# Altium schematic sheet apogee_detection_board.SchDoc
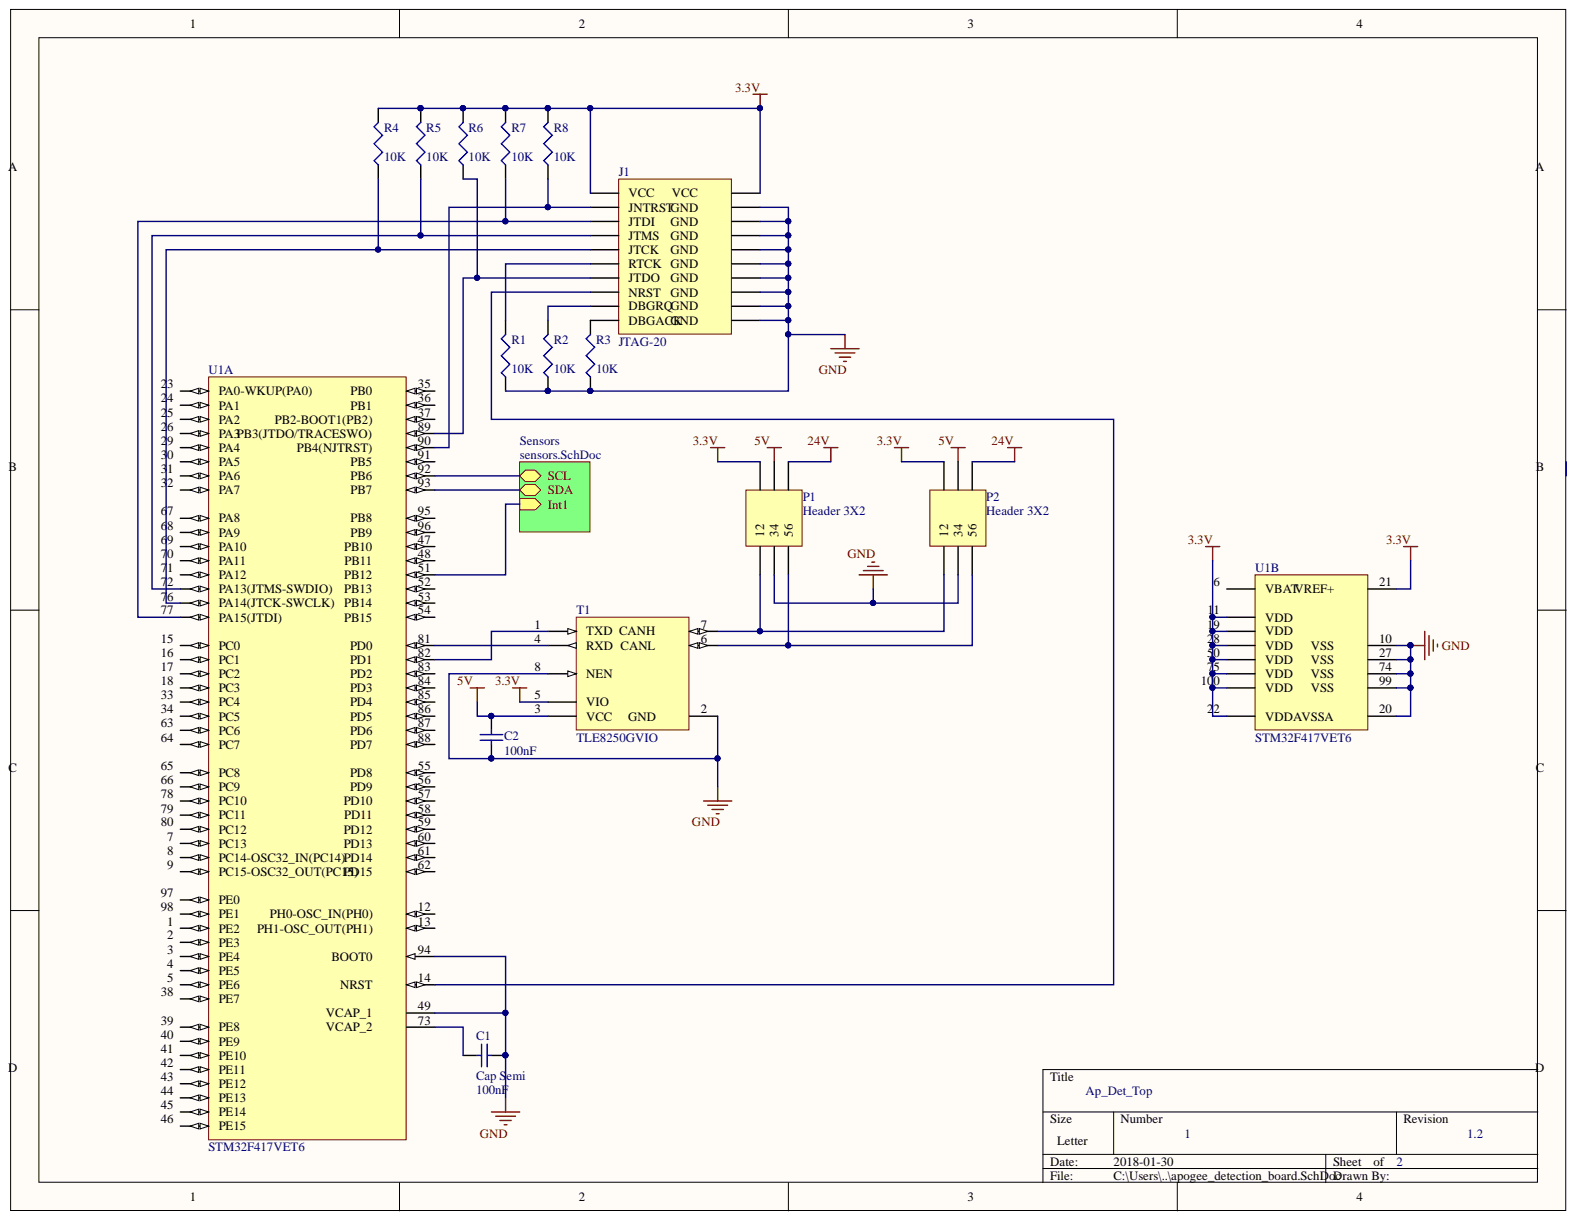
[diagram p0: apogee_detection_board.SchDoc]

## Parts
C1  Cap Semi  value 100nF  [C1206]
C2  value 100nF  [C1206]  (hidden comment: Cap Semi)
J1  JTAG-20  [PCBComponent_1]
P1  Header 3X2  [HDR2X3]
P2  Header 3X2  [HDR2X3]
R1  value 10K  [J1-0603]  (hidden comment: RTCK PD)
R2  value 10K  [J1-0603]  (hidden comment: DBGRQ PD)
R3  value 10K  [J1-0603]  (hidden comment: DBGACK PD)
R4  value 10K  [J1-0603]  (hidden comment: RTCK PD)
R5  value 10K  [J1-0603]  (hidden comment: RTCK PD)
R6  value 10K  [J1-0603]  (hidden comment: RTCK PD)
R7  value 10K  [J1-0603]  (hidden comment: RTCK PD)
R8  value 10K  [J1-0603]  (hidden comment: RTCK PD)
T1  TLE8250GVIO  [INF-PG-DSO-8-16_N]
U1  STM32F417VET6  [STM-LQFP100_N]  (parts A,B)

## Nets
3.3V: J1.1(VCC) J1.2 P1.2 P2.2 R4.2 R5.2 R6.2 R7.2 R8.2 T1.5(VIO) U1.11(VDD) U1.19(VDD) U1.21 U1.22 U1.28(VDD) U1.50(VDD) U1.75(VDD) U1.100(VDD)
5V: C2.2 P1.4 P2.4 T1.3(VCC)
24V: P1.6 P2.6
GND: C1.2 C2.1 J1.4 J1.6 J1.8 J1.10 J1.12 J1.14 J1.16 J1.18 J1.20 P1.3 P2.3 R1.1 R2.1 R3.1 T1.2 T1.8(NEN) U1.10 U1.20 U1.27 U1.49 U1.74 U1.94 U1.99
NetC1_1: C1.1 U1.73
NetJ1_3: J1.3(JNTRSTGND) R8.1 U1.90
NetJ1_5: J1.5(JTDI) R7.1 U1.77(PA15(JTDI))
NetJ1_7: J1.7(JTMS) R5.1 U1.72(PA13(JTMS-SWDIO))
NetJ1_9: J1.9(JTCK) R4.1 U1.76(PA14(JTCK-SWCLK))
NetJ1_11: J1.11(RTCK) R1.2
NetJ1_13: J1.13(JTDO) R6.1 U1.89
NetJ1_15: J1.15(NRST) U1.14
NetJ1_17: J1.17(DBGRQGND) R2.2
NetJ1_19: J1.19(DBGACK) R3.2
NetP1_1: P1.1 P2.1 T1.7
NetP1_5: P1.5 P2.5 T1.6
NetT1_1: T1.1(TXD) U1.82
NetT1_4: T1.4(RXD) U1.81
NetU1_1: U1.1(PE2)
NetU1_2: U1.2(PE3)
NetU1_3: U1.3(PE4)
NetU1_4: U1.4(PE5)
NetU1_5: U1.5(PE6)
NetU1_6: U1.6(VBAT)
NetU1_7: U1.7(PC13)
NetU1_8: U1.8(PC14-OSC32_IN(PC14))
NetU1_9: U1.9(PC15-OSC32_OUT(PC15))
NetU1_12: U1.12
NetU1_13: U1.13
NetU1_15: U1.15(PC0)
NetU1_16: U1.16(PC1)
NetU1_17: U1.17(PC2)
NetU1_18: U1.18(PC3)
NetU1_23: U1.23(PA0-WKUP(PA0))
NetU1_24: U1.24(PA1)
NetU1_25: U1.25(PA2)
NetU1_26: U1.26(PA3)
NetU1_29: U1.29(PA4)
NetU1_30: U1.30(PA5)
NetU1_31: U1.31(PA6)
NetU1_32: U1.32(PA7)
NetU1_33: U1.33(PC4)
NetU1_34: U1.34(PC5)
NetU1_35: U1.35
NetU1_36: U1.36
NetU1_37: U1.37
NetU1_38: U1.38(PE7)
NetU1_39: U1.39(PE8)
NetU1_40: U1.40(PE9)
NetU1_41: U1.41(PE10)
NetU1_42: U1.42(PE11)
NetU1_43: U1.43(PE12)
NetU1_44: U1.44(PE13)
NetU1_45: U1.45(PE14)
NetU1_46: U1.46(PE15)
NetU1_47: U1.47
NetU1_48: U1.48
NetU1_51: U1.51
NetU1_52: U1.52
NetU1_53: U1.53
NetU1_54: U1.54
NetU1_55: U1.55
NetU1_56: U1.56
NetU1_57: U1.57
NetU1_58: U1.58
NetU1_59: U1.59
NetU1_60: U1.60
NetU1_61: U1.61
NetU1_62: U1.62
NetU1_63: U1.63(PC6)
NetU1_64: U1.64(PC7)
NetU1_65: U1.65(PC8)
NetU1_66: U1.66(PC9)
NetU1_67: U1.67(PA8)
NetU1_68: U1.68(PA9)
NetU1_69: U1.69(PA10)
NetU1_70: U1.70(PA11)
NetU1_71: U1.71(PA12)
NetU1_78: U1.78(PC10)
NetU1_79: U1.79(PC11)
NetU1_80: U1.80(PC12)
NetU1_83: U1.83
NetU1_84: U1.84
NetU1_85: U1.85
NetU1_86: U1.86
NetU1_87: U1.87
NetU1_88: U1.88
NetU1_91: U1.91
NetU1_92: U1.92
NetU1_93: U1.93
NetU1_95: U1.95
NetU1_96: U1.96
NetU1_97: U1.97(PE0)
NetU1_98: U1.98(PE1)
N00005:

## Library data of the parts
C1 C2 = Cap Semi: Capacitor (Semiconductor SIM Model); Component Kind: Standard; LatestRevisionDate: 29-May-2009; LatestRevisionNote: IPC-7351 Footprint Added.; Library Name: Miscellaneous Devices.IntLib; PackageDescription: Chip Capacitor; PackageReference: C1206; Published: 8-Jun-2000; Signal Integrity: Cap Semi; Simulation: CAP; Publisher: Altium Limited
J1 = HEADER 10X2: 20-pin JTAG header; Component Kind: Standard; Library Name: Rocket_Connectors.SchLib
P1 P2 = Header 3X2: Header, 3-Pin, Dual row; Component Kind: Standard; LatestRevisionDate: 17-Jul-2002; LatestRevisionNote: Re-released for DXP Platform.; Library Name: Miscellaneous Connectors.IntLib; Signal Integrity: Connector; Publisher: Altium Limited
R1-R8 = Res3: Resistor; Component Kind: Standard; LatestRevisionDate: 29-May-2009; LatestRevisionNote: IPC-7351 Footprint Added.; Library Name: Miscellaneous Devices.IntLib; PackageDescription: Chip Resistor; PackageReference: J1-0603; Published: 8-Jun-2000; Signal Integrity: Res; Simulation: RESISTOR; Publisher: Altium Limited
T1 = TLE8250GVIO: High Speed CAN-Transceiver, 1 Mbit/s Transmission Rate, PG-DSO-8-16, Reel, Green; Manufacturer: Infineon; Bus Wake-up capability: FALSE; Green: TRUE; Quiescent Current (max.): < 15 uA @ 5V standby; Standards: ISO11898-2; Wake-up inputs: no; Component Kind: Standard; ComponentLink1Description: Manufacturer URL; ComponentLink1URL: http://www.infineon.com/; ComponentLink2Description: Datasheet; ComponentLink2URL: http://www.infineon.com/dgdl/TLE8250GVIO_DS_Rev10.pdf?folderId=db3a30431ed1d7b2011edadb13813703&fileId=db3a30432b57a660012b67ea91880b46&location=en.Products.Automotive_ICs.Automotive_Transceivers.CAN_Transceivers.DATASHEET.TLE8250GVIO_DS_Rev10.pdf; ComponentLink3Description: Package Specification; ComponentLink3URL: http://www.infineon.com/dgdl/TLE7259-2GE_DS_rev12.pdf?folderId=db3a3043163797a6011666d32a0c0de1&fileId=db3a3043163797a6011666d4dbb80de2&location=en.Products.Automotive_ICs.Automotive_Transceivers.LIN_Transceivers.DATASHEET.TLE7259-2GE_DS_rev12.pdf; DatasheetVersion: Rev. 1.0; Group: High Speed; Halogen-free: TRUE; Library Name: Infineon_Transceiver.IntLib; Mounting Technology: Surface Mount; PackageDescription: 8-Pin Plastic (Green) Dual Small Outline Package, 4.9 x 3.9 mm Body, 1.27 mm Pitch; PackageReference: PG-DSO-8-16; PackageVersion: Mar-07; Packing: Reel; PartNumber: TLE8250GVIO; Supplier 1: Mouser; Supplier 2: Digi-Key; Supplier Part Number 1: 726-TLE8250GVIO; Supplier Part Number 2: TLE8250GVIO-ND; Transmission rate max: 1 Mbit/s
U1 = STM32F417VET6: ARM Cortex-M4 32-bit MCU+FPU, 210 DMIPS, 512 kB Flash, 192 kB Internal RAM, 82 I/Os, 100-pin LQFP, -40 to 85 degC, Tray; Manufacturer: STMicroelectronics; D/A Converter: 2x12-bit; FLASH Size (Prog) (kB): 512; I/Os (High Current): 82; Internal RAM Size (kB): 192; Operating Frequency(F) (Processor speed) (MHz): 168; Supply Voltage(Vcc) max (V): 3.6; Supply Voltage(Vcc) min (V): 1.8; Component Kind: Standard; ComponentLink1Description: Manufacturer URL; ComponentLink1URL: http://www.st.com/web/en/home.html; ComponentLink2Description: Datasheet; ComponentLink2URL: http://www.st.com/st-web-ui/static/active/en/resource/technical/document/datasheet/DM00035129.pdf; ComponentLink3Description: Package Specification; ComponentLink3URL: http://www.st.com/st-web-ui/static/active/en/resource/technical/document/data_brief/DM00071938.pdf; Core: ARM Cortex-M4; DatasheetVersion: Rev. 4; Library Name: STMicroelectronics STM32 F1.IntLib; Mounting Technology: Surface Mount; PackageDescription: 100-Pin Low Profile Quad Flat Package, 14 x 14 mm Body, 0.5 mm Pitch; PackageReference: LQFP100; PackageVersion: Rev. 1, 06/2013; Packing: Tray; PartNumber: STM32F417VET6; Supplier 1: Digi-Key; Supplier 2: Farnell; Supplier 3: Newark; Supplier 4: Newark; Supplier 5: Mouser; Supplier Part Number 1: 497-11901-ND; Supplier Part Number 2: 2333307; Supplier Part Number 3: 99W9922; Supplier Part Number 4: 94T6496; Supplier Part Number 5: 511-STM32F417VET6
